# Altium schematic sheet boxing.SchDoc
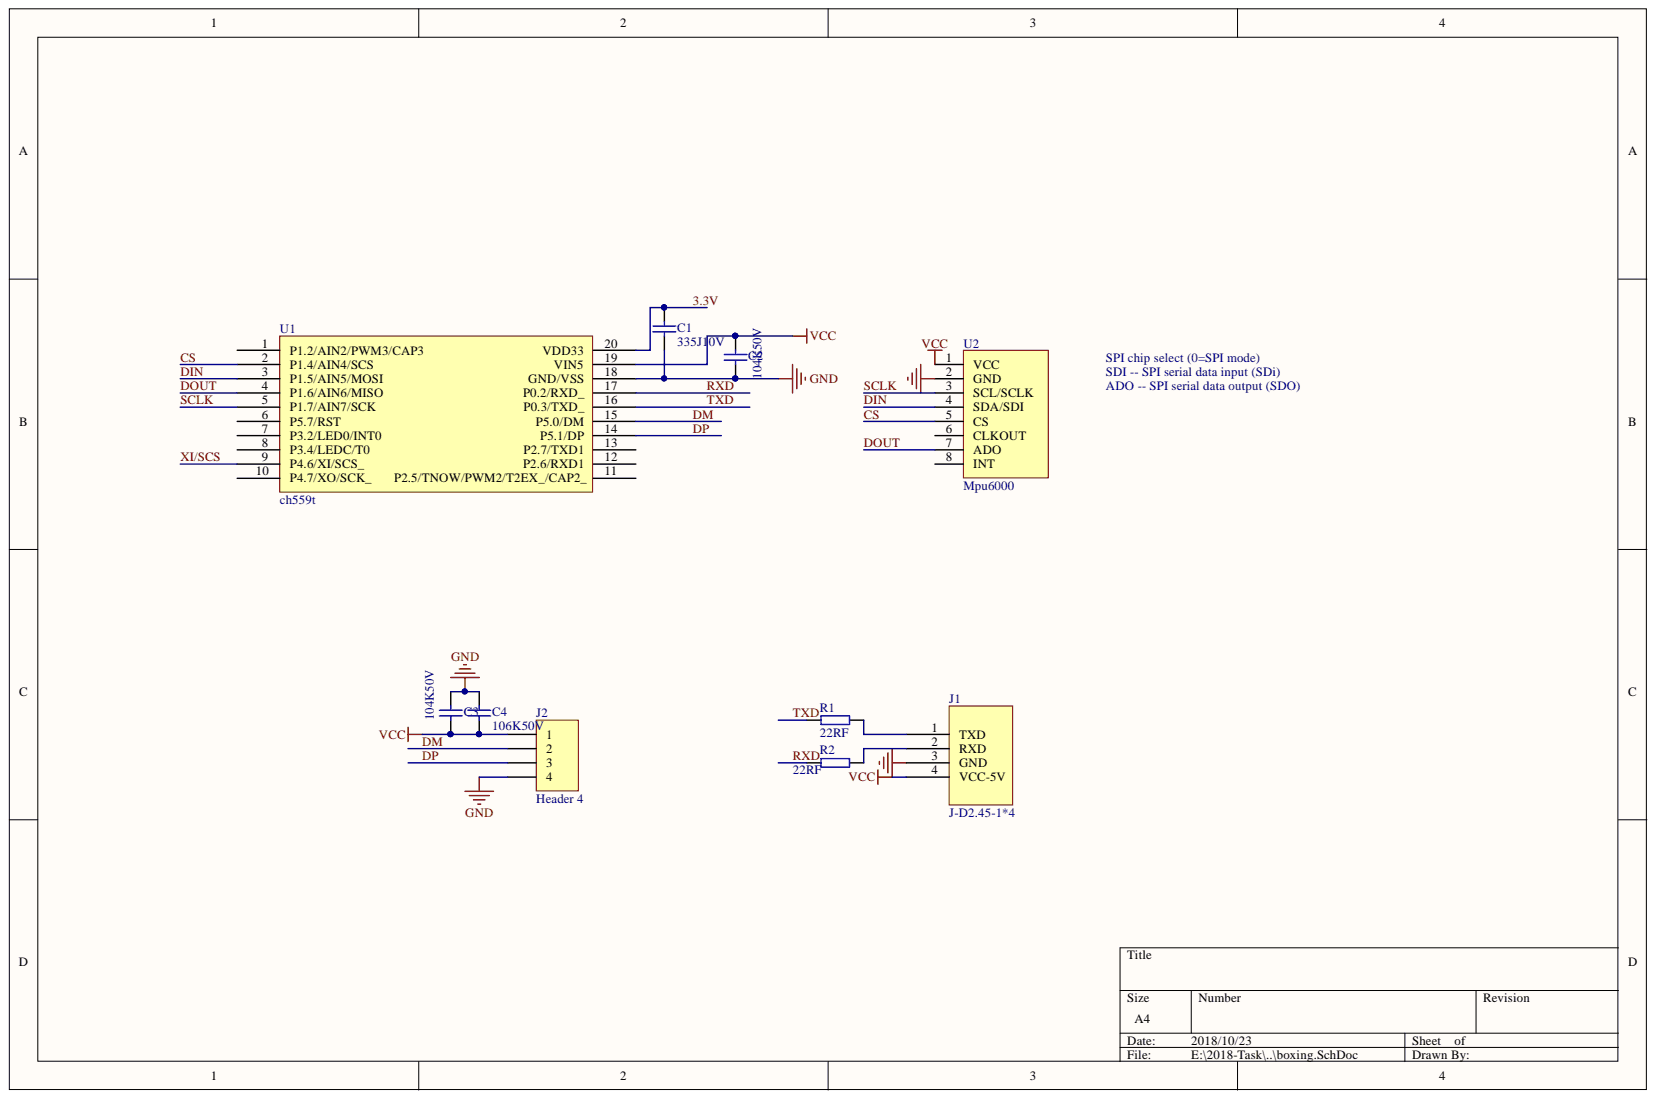
[diagram p0: boxing.SchDoc]

## Parts
C1  335J10V  [C0603]
C2  104K50V  [C0603]
C3  104K50V  [C0603]
C4  106K50V  [C0603]
J1  J-D2.45-1*4  [D2.54-1*4P]
J2  Header 4  [USB-AB]
R1  22RF  [R0603]
R2  22RF  [R0603]
U1  ch559t  [SSOP-20]
U2  Mpu6000

## Nets
DGND: J1.3(GND)
GND: C1.2 C2.2 C3.1 C4.2 J2.4 U1.18(GND/VSS) U2.2(GND)
VCC: C2.1 C3.2 C4.1 J1.4(VCC-5V) J2.1 U1.19(VIN5) U2.1(VCC)
3.3V: C1.1 U1.20(VDD33)
CS: U1.2(P1.4/AIN4/SCS) U2.5(CS)
DIN: U1.3(P1.5/AIN5/MOSI) U2.4(SDA/SDI)
DM: J2.2 U1.15(P5.0/DM)
DOUT: U1.4(P1.6/AIN6/MISO) U2.7(ADO)
DP: J2.3 U1.14(P5.1/DP)
RXD: R2.2 U1.17(P0.2/RXD_)
SCLK: U1.5(P1.7/AIN7/SCK) U2.3(SCL/SCLK)
TXD: R1.2 U1.16(P0.3/TXD_)
XI/SCS: U1.9(P4.6/XI/SCS_)
NetJ1_1: J1.1(TXD) R1.1
NetJ1_2: J1.2(RXD) R2.1

Not connected: U1 1(P1.2/AIN2/PWM3/CAP3) 6(P5.7/RST) 7(P3.2/LED0/INT0) 8(P3.4/LEDC/T0) 10(P4.7/XO/SCK_) 11(P2.5/TNOW/PWM2/T2EX_/CAP2_) 12(P2.6/RXD1) 13(P2.7/TXD1); U2 6(CLKOUT) 8(INT)

## Library data of the parts
C1 = C0603X7R-335J10V: 贴片电容-陶瓷; Component Kind: Standard; Library Name: Capacitor.SchLib
C2 C3 = C0603X7R-104K50V: 贴片电容-陶瓷; 生产商: 风华 三星; 规格型号: 100nF±10%_50V_X7R_C0603; : 无编码 有封装; Component Kind: Standard; Library Name: Capacitor.SchLib
C4 = C0603X7R-106K50V: 贴片电容-陶瓷; 生产商: 风华 三星; 规格型号: 10uF±10%_50V_X7R_C0603; : 无编码 有封装; Component Kind: Standard; Library Name: Capacitor.SchLib
J1 = J-D2.54-1*4单排排母: Component Kind: Standard; Description: J-D2.45-1*4; Library Name: Connector.SchLib
J2 = Header 4: Header, 4-Pin; Component Kind: Standard; LatestRevisionDate: 17-Jul-2002; LatestRevisionNote: Re-released for DXP Platform.; Library Name: Miscellaneous Connectors.IntLib; Publisher: Altium Limited
R1 R2 = R0603-22RF: 贴片电阻-通用; 生产商: 厚声 国巨; 规格型号: 22R±5%_1/10W_±200ppm_R0603; 货品编码: 无样品无编码; Component Kind: Standard; Library Name: Resistor.SchLib
U1 = CH559T: CH559T-20pin; Component Kind: Standard; Library Name: IC.SchLib
U2 = Mpu6000: Component Kind: Standard; Description: MPU6000; Library Name: IC.SchLib
